# Altium schematic sheet GPIO_LCD.SchDoc
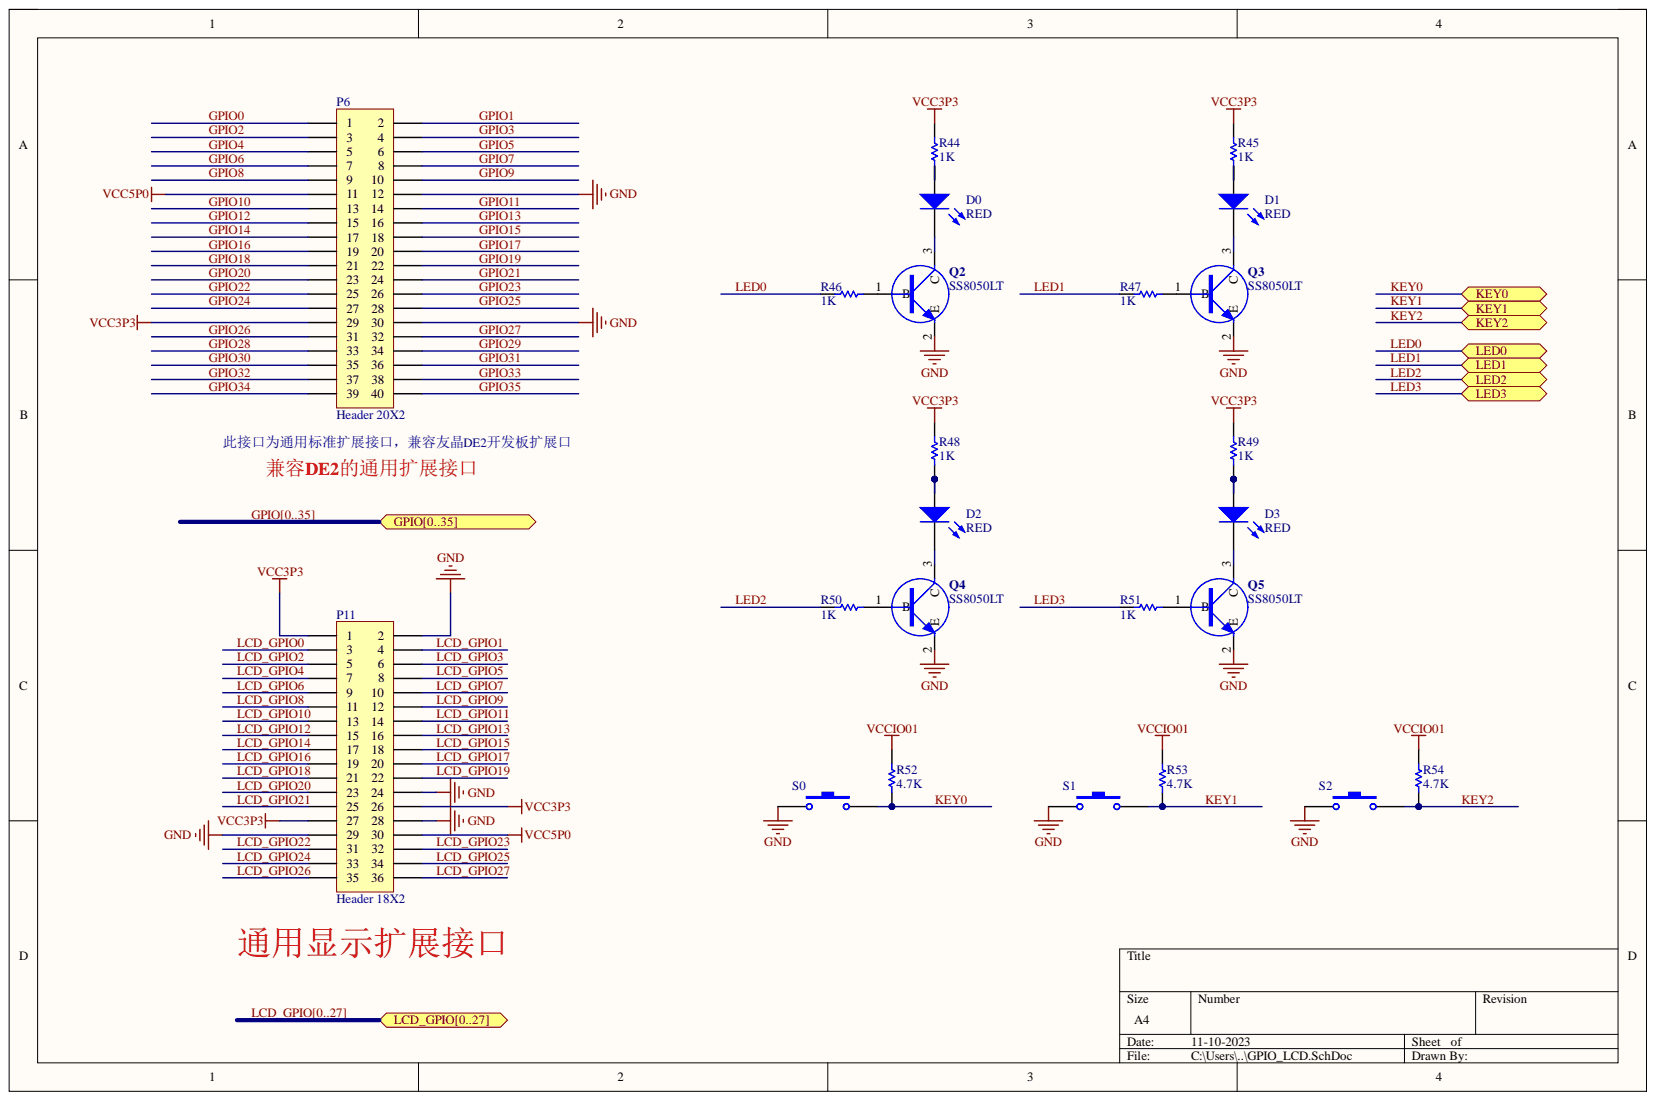
[diagram p0: GPIO_LCD.SchDoc]

## Parts
D0  RED  [0603_LED]
D1  RED  [0603_LED]
D2  RED  [0603_LED]
D3  RED  [0603_LED]
P6  Header 20X2  [HDR2X20]
P11  Header 18X2  [HDR2X18]
Q2  value SS8050LT  [SOT23]  (hidden comment: NPN-1)
Q3  value SS8050LT  [SOT23]  (hidden comment: NPN-1)
Q4  value SS8050LT  [SOT23]  (hidden comment: NPN-1)
Q5  value SS8050LT  [SOT23]  (hidden comment: NPN-1)
R44  1K  [R0402]
R45  1K  [R0402]
R46  1K  [R0402]
R47  1K  [R0402]
R48  1K  [R0402]
R49  1K  [R0402]
R50  1K  [R0402]
R51  1K  [R0402]
R52  4.7K  [R0402]
R53  4.7K  [R0402]
R54  4.7K  [R0402]
S0  SW-PB  [SW4-DIP]  (value not drawn)
S1  SW-PB  [SW4-DIP]  (value not drawn)
S2  SW-PB  [SW4-DIP]  (value not drawn)

## Ports
GPIO0, GPIO1, GPIO2, GPIO3, GPIO4, GPIO5, GPIO6, GPIO7, GPIO8, GPIO9, GPIO10, GPIO11, GPIO12, GPIO13, GPIO14, GPIO15, GPIO16, GPIO17, GPIO18, GPIO19, GPIO20, GPIO21, GPIO22, GPIO23, GPIO24, GPIO25, GPIO26, GPIO27, GPIO28, GPIO29, GPIO30, GPIO31, GPIO32, GPIO33, GPIO34, GPIO35, GPIO[0..35], KEY0, KEY1, KEY2, LCD_GPIO0, LCD_GPIO1, LCD_GPIO2, LCD_GPIO3, LCD_GPIO4, LCD_GPIO5, LCD_GPIO6, LCD_GPIO7, LCD_GPIO8, LCD_GPIO9, LCD_GPIO10, LCD_GPIO11, LCD_GPIO12, LCD_GPIO13, LCD_GPIO14, LCD_GPIO15, LCD_GPIO16, LCD_GPIO17, LCD_GPIO18, LCD_GPIO19, LCD_GPIO20, LCD_GPIO21, LCD_GPIO22, LCD_GPIO23, LCD_GPIO24, LCD_GPIO25, LCD_GPIO26, LCD_GPIO27, LCD_GPIO[0..27], LED0, LED1, LED2, LED3

## Nets
GND: P6.12 P6.30 P11.2 P11.24 P11.28 P11.29 Q2.2 Q3.2 Q4.2 Q5.2 S0.1 S1.1 S2.1
VCC3P3: P6.29 P11.1 P11.26 P11.27 R44.2 R45.2 R48.2 R49.2
VCC5P0: P6.11 P11.30
VCCIO01: R52.2 R53.2 R54.2
GPIO0: P6.1 ; port GPIO[0..35]  [also labelled GPIO]
GPIO1: P6.2 ; port GPIO[0..35]  [also labelled GPIO]
GPIO2: P6.3 ; port GPIO[0..35]  [also labelled GPIO]
GPIO3: P6.4 ; port GPIO[0..35]  [also labelled GPIO]
GPIO4: P6.5 ; port GPIO[0..35]  [also labelled GPIO]
GPIO5: P6.6 ; port GPIO[0..35]  [also labelled GPIO]
GPIO6: P6.7 ; port GPIO[0..35]  [also labelled GPIO]
GPIO7: P6.8 ; port GPIO[0..35]  [also labelled GPIO]
GPIO8: P6.9 ; port GPIO[0..35]  [also labelled GPIO]
GPIO9: P6.10 ; port GPIO[0..35]  [also labelled GPIO]
GPIO10: P6.13 ; port GPIO[0..35]  [also labelled GPIO]
GPIO11: P6.14 ; port GPIO[0..35]  [also labelled GPIO]
GPIO12: P6.15 ; port GPIO[0..35]  [also labelled GPIO]
GPIO13: P6.16 ; port GPIO[0..35]  [also labelled GPIO]
GPIO14: P6.17 ; port GPIO[0..35]  [also labelled GPIO]
GPIO15: P6.18 ; port GPIO[0..35]  [also labelled GPIO]
GPIO16: P6.19 ; port GPIO[0..35]  [also labelled GPIO]
GPIO17: P6.20 ; port GPIO[0..35]  [also labelled GPIO]
GPIO18: P6.21 ; port GPIO[0..35]  [also labelled GPIO]
GPIO19: P6.22 ; port GPIO[0..35]  [also labelled GPIO]
GPIO20: P6.23 ; port GPIO[0..35]  [also labelled GPIO]
GPIO21: P6.24 ; port GPIO[0..35]  [also labelled GPIO]
GPIO22: P6.25 ; port GPIO[0..35]  [also labelled GPIO]
GPIO23: P6.26 ; port GPIO[0..35]  [also labelled GPIO]
GPIO24: P6.27 ; port GPIO[0..35]  [also labelled GPIO]
GPIO25: P6.28 ; port GPIO[0..35]  [also labelled GPIO]
GPIO26: P6.31 ; port GPIO[0..35]  [also labelled GPIO]
GPIO27: P6.32 ; port GPIO[0..35]  [also labelled GPIO]
GPIO28: P6.33 ; port GPIO[0..35]  [also labelled GPIO]
GPIO29: P6.34 ; port GPIO[0..35]  [also labelled GPIO]
GPIO30: P6.35 ; port GPIO[0..35]  [also labelled GPIO]
GPIO31: P6.36 ; port GPIO[0..35]  [also labelled GPIO]
GPIO32: P6.37 ; port GPIO[0..35]  [also labelled GPIO]
GPIO33: P6.38 ; port GPIO[0..35]  [also labelled GPIO]
GPIO34: P6.39 ; port GPIO[0..35]  [also labelled GPIO]
GPIO35: P6.40 ; port GPIO[0..35]  [also labelled GPIO]
KEY0: R52.1 S0.2 ; port KEY0
KEY1: R53.1 S1.2 ; port KEY1
KEY2: R54.1 S2.2 ; port KEY2
LCD_GPIO0: P11.3 ; port LCD_GPIO[0..27]  [also labelled LCD_GPIO]
LCD_GPIO1: P11.4 ; port LCD_GPIO[0..27]  [also labelled LCD_GPIO]
LCD_GPIO2: P11.5 ; port LCD_GPIO[0..27]  [also labelled LCD_GPIO]
LCD_GPIO3: P11.6 ; port LCD_GPIO[0..27]  [also labelled LCD_GPIO]
LCD_GPIO4: P11.7 ; port LCD_GPIO[0..27]  [also labelled LCD_GPIO]
LCD_GPIO5: P11.8 ; port LCD_GPIO[0..27]  [also labelled LCD_GPIO]
LCD_GPIO6: P11.9 ; port LCD_GPIO[0..27]  [also labelled LCD_GPIO]
LCD_GPIO7: P11.10 ; port LCD_GPIO[0..27]  [also labelled LCD_GPIO]
LCD_GPIO8: P11.11 ; port LCD_GPIO[0..27]  [also labelled LCD_GPIO]
LCD_GPIO9: P11.12 ; port LCD_GPIO[0..27]  [also labelled LCD_GPIO]
LCD_GPIO10: P11.13 ; port LCD_GPIO[0..27]  [also labelled LCD_GPIO]
LCD_GPIO11: P11.14 ; port LCD_GPIO[0..27]  [also labelled LCD_GPIO]
LCD_GPIO12: P11.15 ; port LCD_GPIO[0..27]  [also labelled LCD_GPIO]
LCD_GPIO13: P11.16 ; port LCD_GPIO[0..27]  [also labelled LCD_GPIO]
LCD_GPIO14: P11.17 ; port LCD_GPIO[0..27]  [also labelled LCD_GPIO]
LCD_GPIO15: P11.18 ; port LCD_GPIO[0..27]  [also labelled LCD_GPIO]
LCD_GPIO16: P11.19 ; port LCD_GPIO[0..27]  [also labelled LCD_GPIO]
LCD_GPIO17: P11.20 ; port LCD_GPIO[0..27]  [also labelled LCD_GPIO]
LCD_GPIO18: P11.21 ; port LCD_GPIO[0..27]  [also labelled LCD_GPIO]
LCD_GPIO19: P11.22 ; port LCD_GPIO[0..27]  [also labelled LCD_GPIO]
LCD_GPIO20: P11.23 ; port LCD_GPIO[0..27]  [also labelled LCD_GPIO]
LCD_GPIO21: P11.25 ; port LCD_GPIO[0..27]  [also labelled LCD_GPIO]
LCD_GPIO22: P11.31 ; port LCD_GPIO[0..27]  [also labelled LCD_GPIO]
LCD_GPIO23: P11.32 ; port LCD_GPIO[0..27]  [also labelled LCD_GPIO]
LCD_GPIO24: P11.33 ; port LCD_GPIO[0..27]  [also labelled LCD_GPIO]
LCD_GPIO25: P11.34 ; port LCD_GPIO[0..27]  [also labelled LCD_GPIO]
LCD_GPIO26: P11.35 ; port LCD_GPIO[0..27]  [also labelled LCD_GPIO]
LCD_GPIO27: P11.36 ; port LCD_GPIO[0..27]  [also labelled LCD_GPIO]
LED0: R46.1 ; port LED0
LED1: R47.1 ; port LED1
LED2: R50.1 ; port LED2
LED3: R51.1 ; port LED3
NetD0_1: D0.1 R44.1
NetD0_2: D0.2 Q2.3
NetD1_1: D1.1 R45.1
NetD1_2: D1.2 Q3.3
NetD2_1: D2.1 R48.1
NetD2_2: D2.2 Q4.3
NetD3_1: D3.1 R49.1
NetD3_2: D3.2 Q5.3
NetQ2_1: Q2.1 R46.2
NetQ3_1: Q3.1 R47.2
NetQ4_1: Q4.1 R50.2
NetQ5_1: Q5.1 R51.2

## Library data of the parts
D0-D3 = LED2: Typical RED, GREEN, YELLOW, AMBER GaAs LED; Component Kind: Standard; LatestRevisionDate: 17-Jul-2002; LatestRevisionNote: Re-released for DXP Platform.; Library Name: Miscellaneous Devices.IntLib; PackageDescription: SM LED; 2 Flat Leads; PackageReference: 3.2X1.6X1.1; PackageVersion: Feb-2002; Published: 8-Jun-2000; Value: RED; Publisher: Altium Limited
P6 = Header 20X2: IDC3-40，2.54mm，双排2*20简牛; Component Kind: Standard; LatestRevisionDate: 17-Jul-2002; LatestRevisionNote: Re-released for DXP Platform.; Library Name: Miscellaneous Connectors.IntLib; Signal Integrity: Connector; Publisher: Altium Limited
P11 = Header 18X2: Header, 18-Pin, Dual row; Component Kind: Standard; LatestRevisionDate: 17-Jul-2002; LatestRevisionNote: Re-released for DXP Platform.; Library Name: AC620V1.0.IntLib; Signal Integrity: Connector; Publisher: Altium Limited
Q2-Q5 = (no symbol name): SS8050LT(1.5A),SOT-23,江苏长电,RoHS; 名称: 三极管; 物料代码: 1.04.09.0001; 设备封装名称: SOT-23_SS8050LT; 贴片和直插: SMT; Component Kind: Standard; ComponentLink1Description: ErpDataInfo; ComponentLink1URL: http://erp.zlgmcu.com/ErpExt/ErpData/ListMateriel.aspx?pkey=1.04.09.0001; Library Name: zynq.SCHLIB; RoHS: RoHS; 厂商: 江苏长电; 型号: SS8050LT(1.5A); 封装: SOT-23
R44-R54 = Res1: Resistor; Component Kind: Standard; LatestRevisionDate: 17-Jul-2002; LatestRevisionNote: Re-released for DXP Platform.; Library Name: Miscellaneous Devices.IntLib; PackageDescription: Axial Device, Thru-Hole; 2 Leads; 0.3 in Pin Spacing; PackageReference: AXIAL-0.3; Published: 8-Jun-2000; Signal Integrity: Res; Simulation: RESISTOR; Publisher: Altium Limited
  R44-R51: Value: 1K
  R52-R54: Value: 4.7K
S0-S2 = SW-PB: 6*6*4.5; Component Kind: Standard; LatestRevisionDate: 17-Jul-2002; LatestRevisionNote: Re-released for DXP Platform.; Library Name: zynq.SCHLIB; PackageDescription: Switch; 2 Leads; PackageReference: SPST-2; Published: 24-Mar-1999; Publisher: Altium Limited
